# Altium schematic sheet ToF_Maindeck.SchDoc
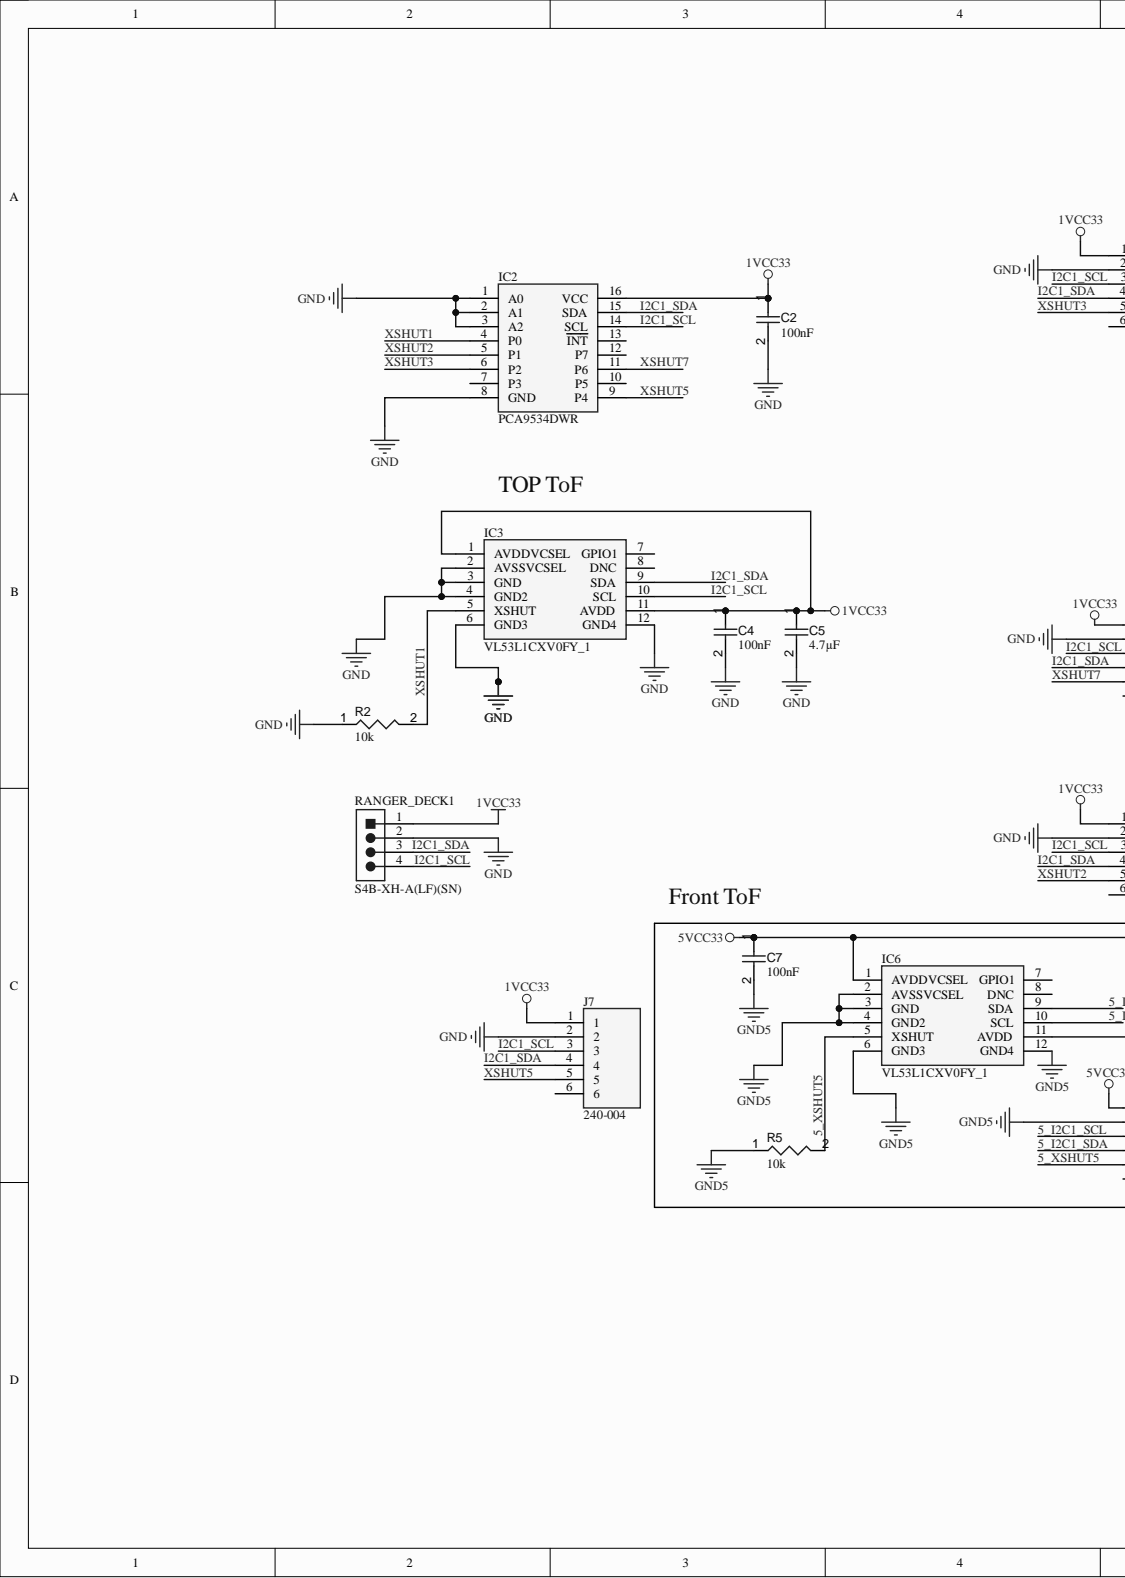
[diagram p0: ToF_Maindeck.SchDoc, left]
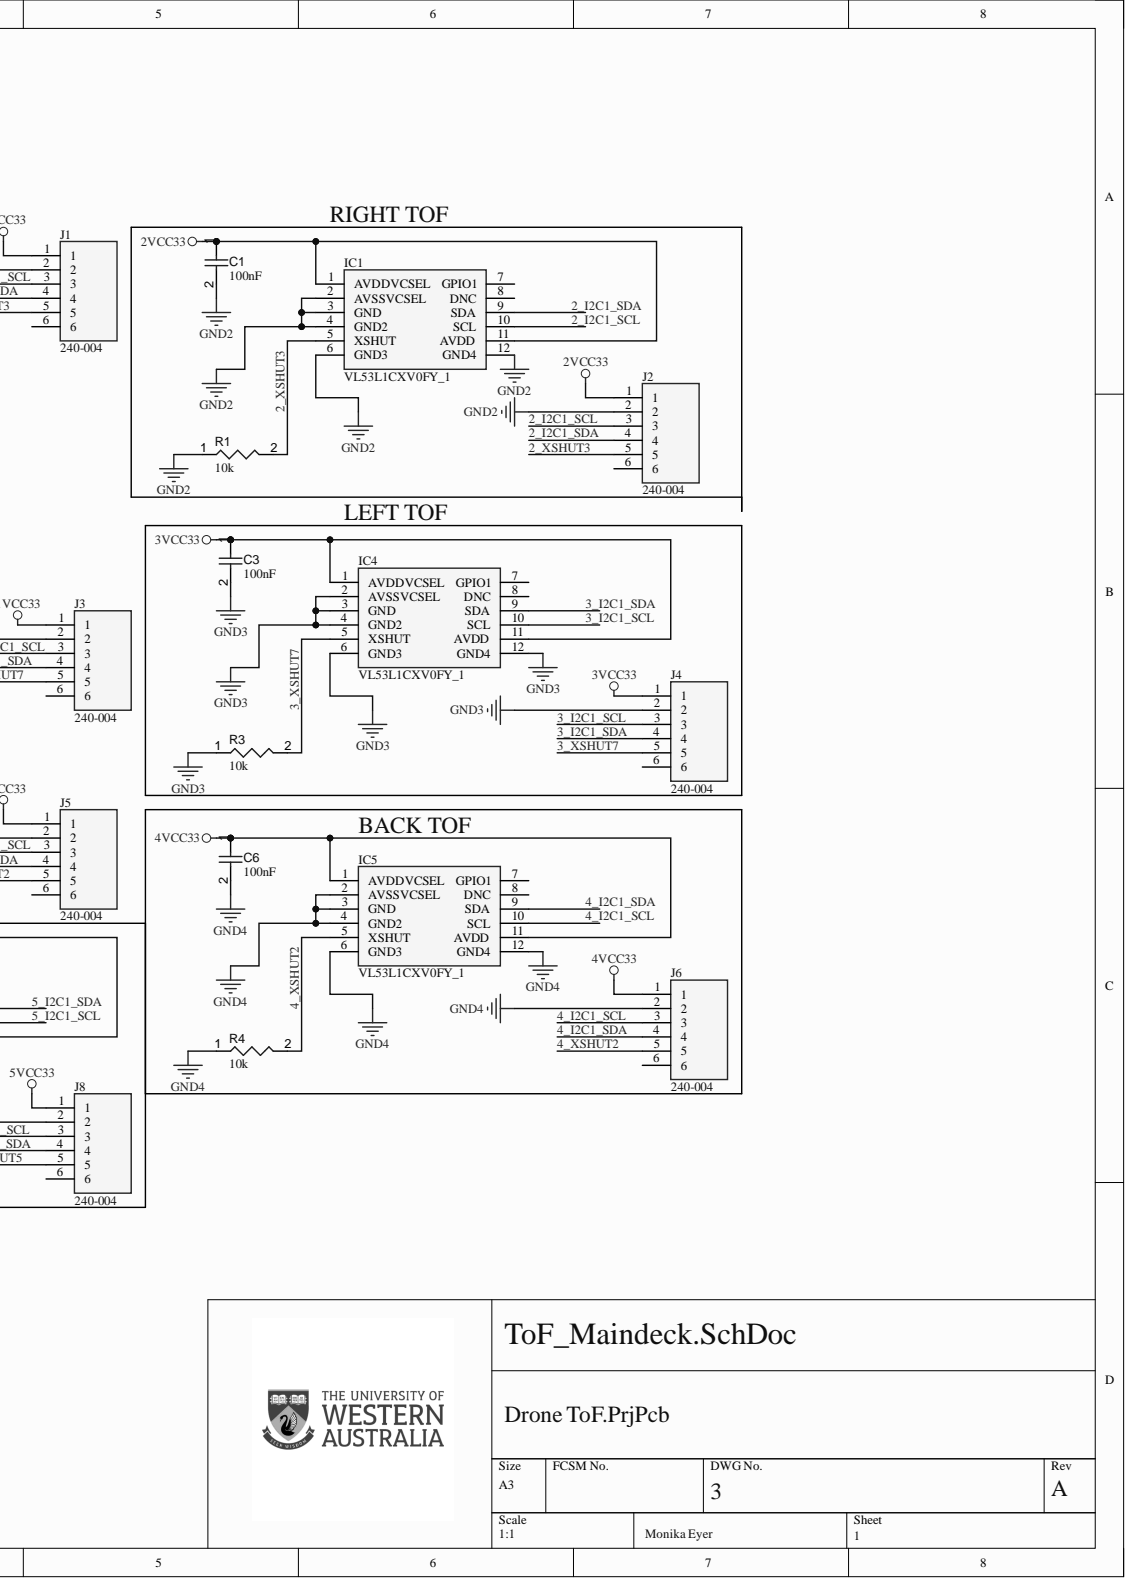
[diagram p1: ToF_Maindeck.SchDoc, right]

## Parts
C1  value 100nF  [CAPC1608X90X40NL10T20]  (hidden comment: Capacitor 100 nF +/-10% 50 V 0603)
C2  value 100nF  [CAPC1608X90X40NL10T20]  (hidden comment: Capacitor 100 nF +/-10% 50 V 0603)
C3  value 100nF  [CAPC1608X90X40NL10T20]  (hidden comment: Capacitor 100 nF +/-10% 50 V 0603)
C4  value 100nF  [CAPC1608X90X40NL10T20]  (hidden comment: Capacitor 100 nF +/-10% 50 V 0603)
C5  value 4.7µF  [CAPC0603(1608)100_L]  (hidden comment: Capacitor 4.7µF +/-20% 10V 0603)
C6  value 100nF  [CAPC1608X90X40NL10T20]  (hidden comment: Capacitor 100 nF +/-10% 50 V 0603)
C7  value 100nF  [CAPC1608X90X40NL10T20]  (hidden comment: Capacitor 100 nF +/-10% 50 V 0603)
IC1  VL53L1CXV0FY_1  [VL53L1CXV0FY1]
IC2  PCA9534DWR  [SOIC127P1030X265-16N]
IC3  VL53L1CXV0FY_1  [VL53L1CXV0FY1]
IC4  VL53L1CXV0FY_1  [VL53L1CXV0FY1]
IC5  VL53L1CXV0FY_1  [VL53L1CXV0FY1]
IC6  VL53L1CXV0FY_1  [VL53L1CXV0FY1]
J1  240-004  [HDRV6W64P0X254_1X6_1524X254X909P]
J2  240-004  [HDRV6W64P0X254_1X6_1524X254X909P]
J3  240-004  [HDRV6W64P0X254_1X6_1524X254X909P]
J4  240-004  [HDRV6W64P0X254_1X6_1524X254X909P]
J5  240-004  [HDRV6W64P0X254_1X6_1524X254X909P]
J6  240-004  [HDRV6W64P0X254_1X6_1524X254X909P]
J7  240-004  [HDRV6W64P0X254_1X6_1524X254X909P]
J8  240-004  [HDRV6W64P0X254_1X6_1524X254X909P]
R1  value 10k  [RESC1608X55X25ML10T15]  (hidden comment: Resistor 10k +/-1% 0603 100 mW)
R2  value 10k  [RESC1608X55X25ML10T15]  (hidden comment: Resistor 10k +/-1% 0603 100 mW)
R3  value 10k  [RESC1608X55X25ML10T15]  (hidden comment: Resistor 10k +/-1% 0603 100 mW)
R4  value 10k  [RESC1608X55X25ML10T15]  (hidden comment: Resistor 10k +/-1% 0603 100 mW)
R5  value 10k  [RESC1608X55X25ML10T15]  (hidden comment: Resistor 10k +/-1% 0603 100 mW)
RANGER_DECK1  S4B-XH-A(LF)(SN)  [JST-S4B-XH-A(LF)(SN)_V]

## Nets
1VCC33: C2.1 C4.1 C5.1 IC2.16(VCC) IC3.1(AVDDVCSEL) IC3.11(AVDD) J1.1 J3.1 J5.1 J7.1 RANGER_DECK1.1
2VCC33: C1.1 IC1.1(AVDDVCSEL) IC1.11(AVDD) J2.1
3VCC33: C3.1 IC4.1(AVDDVCSEL) IC4.11(AVDD) J4.1
4VCC33: C6.1 IC5.1(AVDDVCSEL) IC5.11(AVDD) J6.1
5VCC33: C7.1 IC6.1(AVDDVCSEL) IC6.11(AVDD) J8.1
GND: C2.2 C4.2 C5.2 IC2.1(A0) IC2.2(A1) IC2.3(A2) IC2.8(GND) IC3.2(AVSSVCSEL) IC3.3(GND) IC3.4(GND2) IC3.6(GND3) IC3.12(GND4) J1.2 J3.2 J5.2 J7.2 R2.1 RANGER_DECK1.2
GND2: C1.2 IC1.2(AVSSVCSEL) IC1.3(GND) IC1.4(GND2) IC1.6(GND3) IC1.12(GND4) J2.2 R1.1
GND3: C3.2 IC4.2(AVSSVCSEL) IC4.3(GND) IC4.4(GND2) IC4.6(GND3) IC4.12(GND4) J4.2 R3.1
GND4: C6.2 IC5.2(AVSSVCSEL) IC5.3(GND) IC5.4(GND2) IC5.6(GND3) IC5.12(GND4) J6.2 R4.1
GND5: C7.2 IC6.2(AVSSVCSEL) IC6.3(GND) IC6.4(GND2) IC6.6(GND3) IC6.12(GND4) J8.2 R5.1
2_I2C1_SCL: IC1.10(SCL) J2.3
2_I2C1_SDA: IC1.9(SDA) J2.4
2_XSHUT3: IC1.5(XSHUT) J2.5 R1.2
3_I2C1_SCL: IC4.10(SCL) J4.3
3_I2C1_SDA: IC4.9(SDA) J4.4
3_XSHUT7: IC4.5(XSHUT) J4.5 R3.2
4_I2C1_SCL: IC5.10(SCL) J6.3
4_I2C1_SDA: IC5.9(SDA) J6.4
4_XSHUT2: IC5.5(XSHUT) J6.5 R4.2
5_I2C1_SCL: IC6.10(SCL) J8.3
5_I2C1_SDA: IC6.9(SDA) J8.4
5_XSHUT5: IC6.5(XSHUT) J8.5 R5.2
I2C1_SCL: IC2.14(SCL) IC3.10(SCL) J1.3 J3.3 J5.3 J7.3 RANGER_DECK1.4
I2C1_SDA: IC2.15(SDA) IC3.9(SDA) J1.4 J3.4 J5.4 J7.4 RANGER_DECK1.3
XSHUT1: IC2.4(P0) IC3.5(XSHUT) R2.2
XSHUT2: IC2.5(P1) J5.5
XSHUT3: IC2.6(P2) J1.5
XSHUT5: IC2.9(P4) J7.5
XSHUT7: IC2.11(P6) J3.5

Not connected: IC1 7(GPIO1) 8(DNC); IC2 7(P3) 10(P5) 12(P7) 13(INT); IC3 7(GPIO1) 8(DNC); IC4 7(GPIO1) 8(DNC); IC5 7(GPIO1) 8(DNC); IC6 7(GPIO1) 8(DNC); J1 6; J2 6; J3 6; J4 6; J5 6; J6 6; J7 6; J8 6

## Library data of the parts
C1-C4 C6 C7 = CMP-001-00017-6: Chip Capacitor, 100 nF, +/- 10%, 50 V, 0603 (1608 Metric); Capacitor Type: Ceramic; Voltage Rating: 50V; Case/Package: 0603; Component Kind: Standard; Max Operating Temperature: 125°C; Min Operating Temperature: -55°C; Mounting Technology: SM; Pins: 2; RoHS Compliant: True; Tolerance: 10%; Library Name: Ray Prasetya
C5 = CMP-001-00076-4: Chip Capacitor, 4.7µF +/-20%, 10V, 0603, Thickness 1 mm; Tolerance: 10%; Case/Package: 0603; Component Kind: Standard; Max Operating Temperature: 85°C; Min Operating Temperature: -55°C; Mounting Technology: SMT; Pins: 2; Voltage Rating: 10V; Library Name: Ray Prasetya
IC1 IC3-IC6 = VL53L1CXV0FY_1: Integrated Circuit; Manufacturer_Name: STMicroelectronics; Manufacturer_Part_Number: VL53L1CXV0FY/1; Arrow Part Number: VL53L1CXV0FY/1; Arrow Price/Stock: https://www.arrow.com/en/products/vl53l1cxv0fy1/stmicroelectronics?utm_currency=USD&region=europe; Component Kind: Standard; Datasheet Link: https://componentsearchengine.com/Datasheets/1/VL53L1CXV0FY_1.pdf; Height: 1mm; Mouser Part Number: 511-VL53L1CXV0FY/1; Mouser Price/Stock: https://www.mouser.co.uk/ProductDetail/STMicroelectronics/VL53L1CXV0FY-1?qs=W0yvOO0ixfFQE5v5L6h6PA%3D%3D
IC2 = PCA9534DWR: Integrated Circuit; Manufacturer_Name: Texas Instruments; Arrow Part Number: PCA9534DWR; Arrow Price/Stock: https://www.arrow.com/en/products/pca9534dwr/texas-instruments?region=nac; Component Kind: Standard; Datasheet Link: http://www.ti.com/lit/gpn/pca9534; Height: 2.65mm; Manufacturer_Part_Number: PCA9534DWR; Mouser Part Number: 595-PCA9534DWR; Mouser Price/Stock: https://www.mouser.co.uk/ProductDetail/Texas-Instruments/PCA9534DWR?qs=iSMark9AYDWOs18EtjSqPQ%3D%3D
J1-J8 = 240-004: Connector; Manufacturer_Name: Digilent; Arrow Part Number: 240-004; Arrow Price/Stock: https://www.arrow.com/en/products/240-004/digilent?utm_currency=USD&region=nac; Component Kind: Standard; Datasheet Link: https://digilent.com/reference/_media/reference/test-and-measurement/6-pin-header-gender-changer/163-068-2.pdf; Height: 9.09mm; Manufacturer_Part_Number: 240-004
R1-R5 = CMP-009-00017-5: Chip Resistor, 10 KOhm, +/- 1%, 01 W, -55 to 155 degC, 0603 (1608 Metric); Power: 100mW; Voltage Rating: 50V; Case/Package: 0603; Component Kind: Standard; Max Operating Temperature: 155°C; Min Operating Temperature: -55°C; Mounting Technology: SM; Pins: 2; RoHS Compliant: True; Tolerance: 1%; Library Name: Ray Prasetya
RANGER_DECK1 = CMP-002-00034-2: Male Header, Pitch 2.5 mm, 1 x 4 Position, Height 6.1 mm, Tail Length 3.4 mm, -25 to 85 degC, RoHS, Bulk; Manufacturer: JST; Case/Package: S4B-XH-A(LF)(SN); Component Kind: Standard; Connector Type: Header; Datasheet URL: http://www.jst-mfg.com/product/pdf/eng/eXH.pdf; Datasheet Version: May-16; Gender: Male; Manufacturer URL: http://www.jst.com/home2.html; Max Operating Temperature: 85°C; Min Operating Temperature: -25°C; Mounting Technology: TH; Package Description: XH Series Disconnectable Crimp Style Connector, Pitch 2.5 mm, 1 x 4 Position, Height 6.1 mm, Tail Length 3.4 mm; Package Reference: S4B-XH-A(LF)(SN); RoHS Compliant: Unknown; Library Name: Ray Prasetya
